# Altium schematic sheet lv_com_rs232_rs485.SchDoc(lv_com_rs232_rs485)
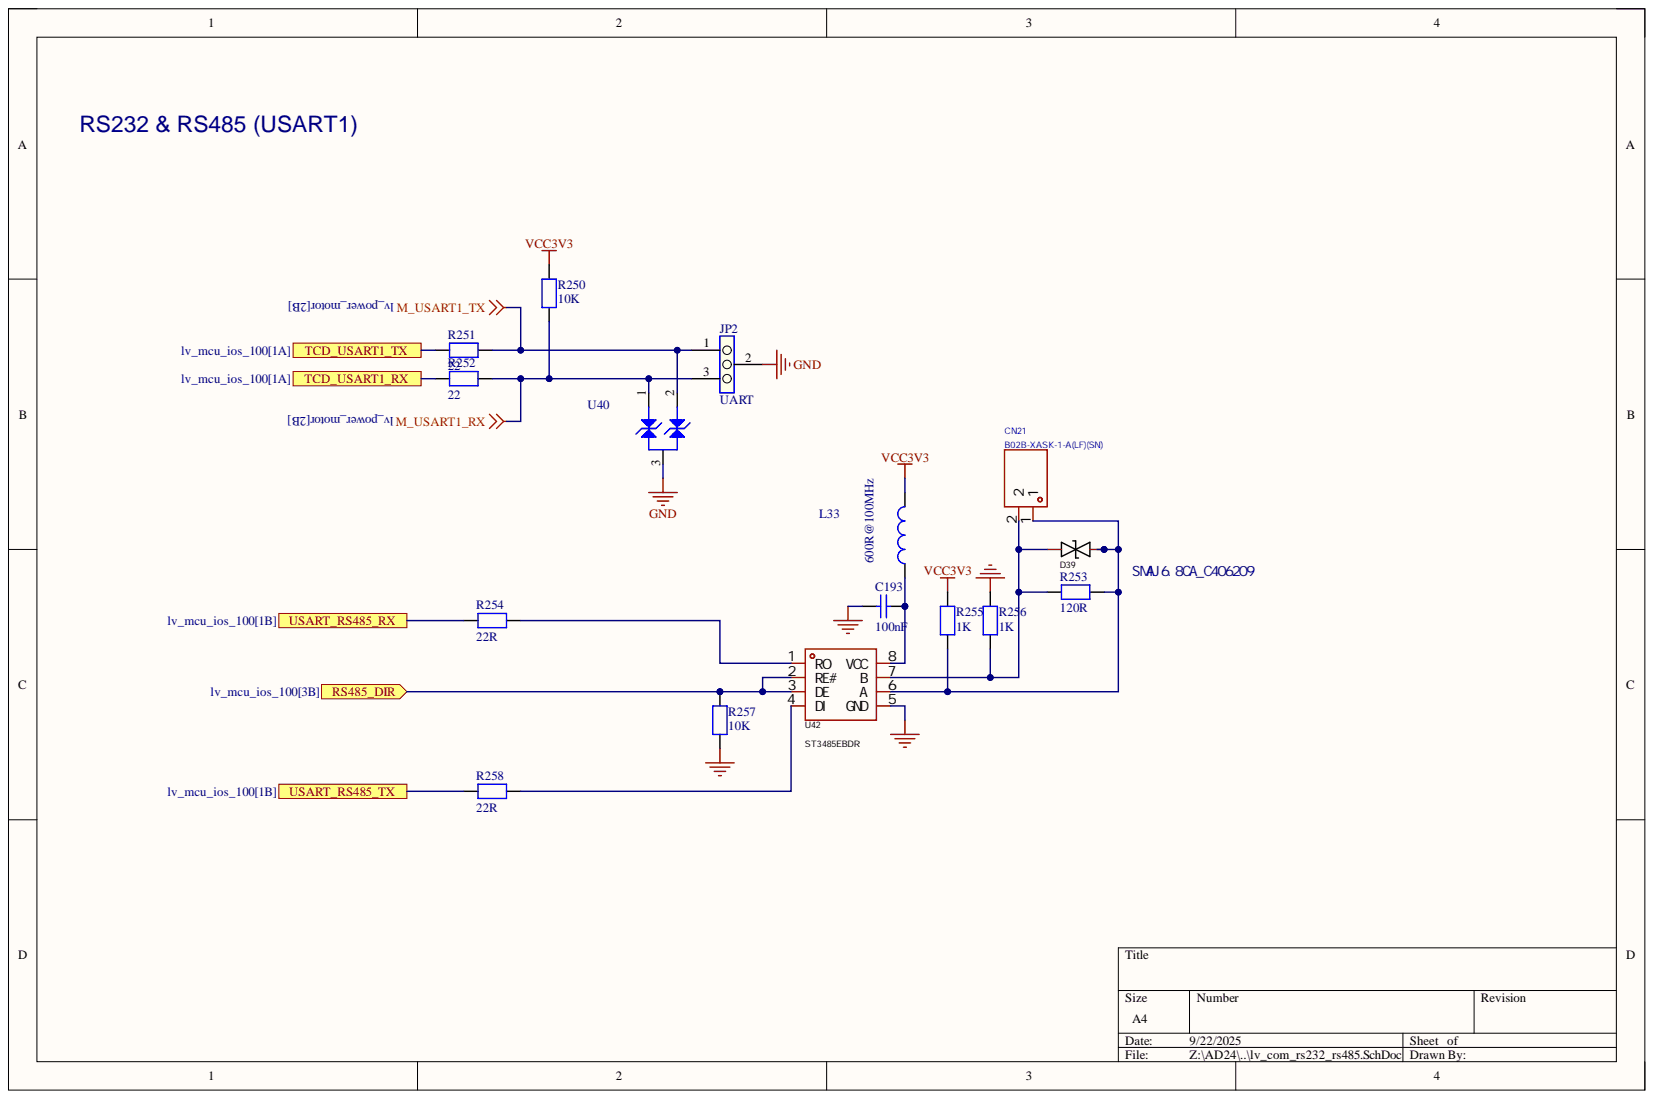
[diagram p0: lv_com_rs232_rs485.SchDoc]

## Parts
C193  100nF  [C0402]
CN21  B02B-XASK-1-A(LF)(SN)  [CONN-TH_B02B-XASK-1-A-LF-SN]
D39  SMAJ6.8CA_C406209  [SMA_L4.4-W2.6-LS5.0-BI]
JP2  UART  [CON_SAMTEC_TSW-103-07-F-S]
L33  600R@100MHz  [L0603]
R250  10K  [R0402]
R251  22  [R0402]
R252  22  [R0402]
R253  120R  [0805]
R254  22R  [R0402]
R255  1K  [R0603]
R256  1K  [R0603]
R257  10K  [R0402]
R258  22R  [R0402]
U40  ESDCAN03-2BWY  [DIO_SOT323]  (value not drawn)
U42  ST3485EBDR  [SO-8_L4.9-W3.9-P1.27-LS5.9-BL]

## Ports
RS485_DIR, TCD_USART1_RX, TCD_USART1_TX, USART_RS485_RX, USART_RS485_TX

## Nets
GND: C193.1 JP2.2 R256.2 R257.1 U40.3 U42.5(GND)
M_USART1_RX: JP2.3 R250.1 R252.2 U40.1
M_USART1_TX: JP2.1 R251.2 U40.2
VCC3V3: L33.2 R250.2 R255.2
RS485_DIR: R257.2 U42.2(RE#) U42.3(DE) ; port RS485_DIR
TCD_USART1_RX: R252.1 ; port TCD_USART1_RX
TCD_USART1_TX: R251.1 ; port TCD_USART1_TX
USART_RS485_RX: R254.1 ; port USART_RS485_RX
USART_RS485_TX: R258.1 ; port USART_RS485_TX
NetC193_2: C193.2 L33.1 U42.8(VCC)
NetCN21_1: CN21.1 D39.2 R253.1 R255.1 U42.6(A)
NetCN21_2: CN21.2 D39.1 R253.2 R256.1 U42.7(B)
NetR254_2: R254.2 U42.1(RO)
NetR258_2: R258.2 U42.4(DI)

## Library data of the parts
C193 = Capacitor: 50V, 10%, X7R; Manufacturer_Part_Number: GRM155R61E105KA12D; Component Kind: Standard; ComponentLink1Description: Datasheet; ComponentLink1URL: http://tunmcdsvn.tun.st.com/Component_Datasheet_Library/Trunk/Capacitors/Yageo_Capacitor_NP0_16V-to-50V; Library Name: HiPin_Library_AD.IntLib; Package: C0402; Value: 100nF
CN21 = B02B-XASK-1-A(LF)(SN): XA插座，间距: 2.5mm，直插，H8.8mm; Manufacturer: JST; Add into BOM: yes; Current Rating (Max): 3A; Flame Retardant Rating: UL94V-0; Number of PINs Per Row: 2; Pins Structure: 1x2P; Pitch: 2.5mm; Plastic Material: 玻纤增强PA66; Rated Voltage (Max): 250V; Reference Series: XA; Supplementary Features: 带柱；打K脚; X-Length of Bottom Edge on Board (Spacing Line): 7.5mm; Y-Width of Bottom Edge on Board: 6.4mm; Z-Height of the Board: 8.8mm; Colour: 白色; Component Kind: Standard; Contact Material: 铜合金; Contact Plating: 锡; Convert to PCB: yes; Datasheet: https://atta.szlcsc.com/upload/public/pdf/source/20180829/C265077_AACBE43BFB9344D794AFC81CB60287FF.pdf; Device: B02B-XASK-1-A(LF)(SN); JLCPCB Part Class: Extended Part; LCSC Part Name: 1x2P 间距:2.5mm 直插 系列:XA; Library Name: HiPin_Library_AD.IntLib; Manufacturer Part: B02B-XASK-1-A(LF)(SN); Mounting Style: 直插; Number of Pins: 2P; Number of Rows: 1; Operating Temperature Range: -25℃~+85℃; PCB3D: CONN-TH_B02B-XASK-1-A-LF-SN; Row Spacing: -; Supplier: LCSC; Supplier Footprint: 插件,P=2.5mm; Supplier Part: C265077; Symbol: B02B-XASK-1-A(LF)(SN)
D39 = SMAJ6.8CA_C406209: Manufacturer: BORN(�����뵼��); Add into BOM: yes; Reverse Stand-Off Voltage (Vrwm): 6.8V; Type: TVS; Component Kind: Standard; Convert to PCB: yes; Datasheet: https://atta.szlcsc.com/upload/public/pdf/source/20190726/C406209_A574BC8626299E1E05AC1B67D2991239.pdf; Device: SMAJ6.8CA_C406209; JLCPCB Part Class: Extended Part; LCSC Part Name: ˫��TVS 6.8V��ֹ; Library Name: HiPin_Library_AD.IntLib; Manufacturer Part: SMAJ6.8CA; Supplier: LCSC; Supplier Footprint: SMA(DO-214AC); Supplier Part: C406209; Symbol: SMAJ6.8CA
JP2 = MCD-00111: Header, 3x1, 2,54mm, VR, TH, Height 5.84mm/2.54mm; Manufacturer: SAMTEC; Manufacturer_Part_Number: TSW-103-07-F-S; Part_Type: Connector; Initial_Board_Reference: MB1262; Item Type: Item; Path: ws/MCD_ApplicationBoards/Lists/MCDPARTSdatabase; Provided_By: SUBCO; Value: Header 3x1; Component Kind: Standard; ComponentLink1Description: Datasheet; ComponentLink1URL: http://tunmcdsvn.tun.st.com/Component_Datasheet_Library/Trunk/Connectors/Samtec_TSW-HTSW-series; Internal_Part_Number: MCD-00111; Library Name: MB1397.IntLib; Status: Released
L33 = MCD-00047: +/-25%, 350mA, 500mohm DC; Manufacturer: TAI-TECH; Manufacturer_Part_Number: FCM1608KF-601T03; Database Table Name: MCD_PARTS$; Component Kind: Standard; ComponentLink1Description: Datasheet; ComponentLink1URL: http://tunmcdsvn.tun.st.com/Component_Datasheet_Library/Trunk/Inductors/TaiTech_Bead_FCM1608_series; Library Name: MCD_PARTS_database.DbLib
R250 R257 = RES: 1%; Component Kind: Standard; Library Name: HiPin_Library_AD.IntLib; Value: 10K
R251-R256 R258 = RES: 1%; Value: 10K; Component Kind: Standard; Library Name: HiPin_Library_AD.IntLib
U40 = MCD-01228: 极性  双向  反向截止电压(Vrwm)  24V  钳位电压  41V  峰值脉冲电流(Ipp)  3.7A@8/20us; Manufacturer: STMICROELECTRONICS; Database Table Name: MCD_PARTS$; Component Kind: Standard; Library Name: MCD_PARTS_database.DbLib; Manufacturer_Part_Number: ESDCAN03-2BWY
U42 = ST3485EBDR: RS485; Manufacturer: ST(意法半导体); Add into BOM: yes; Data Rate: 15Mbps; ESD Protect: ±12kV; Node Number: 64; Number of Driver: 1; Supply Voltage: 3V~3.6V; Types: 收发器; Component Kind: Standard; Convert to PCB: yes; Datasheet: https://atta.szlcsc.com/upload/public/pdf/source/20181012/C75809_EC9EE9FC1B4AC7950854237FAEE73CA1.pdf; Device: ST3485EBDR; JLCPCB Part Class: Extended Part; LCSC Part Name: ST3485EBDR 3.3 V供电，15 kV ESD保护，最高12 Mbps RS-485/RS-422收发器; Library Name: HiPin_Library_AD.IntLib; Manufacturer Part: ST3485EBDR; Number of receiver: 1; Operating Temperature: -40℃~+85℃; PCB3D: SO-8_L4.9-W3.9-H1.5-LS5.9-P1.27; Supplier: LCSC; Supplier Footprint: SO-8; Supplier Part: C75809; Symbol: ST3485EBDR
